# Altium schematic sheet MCU.SchDoc
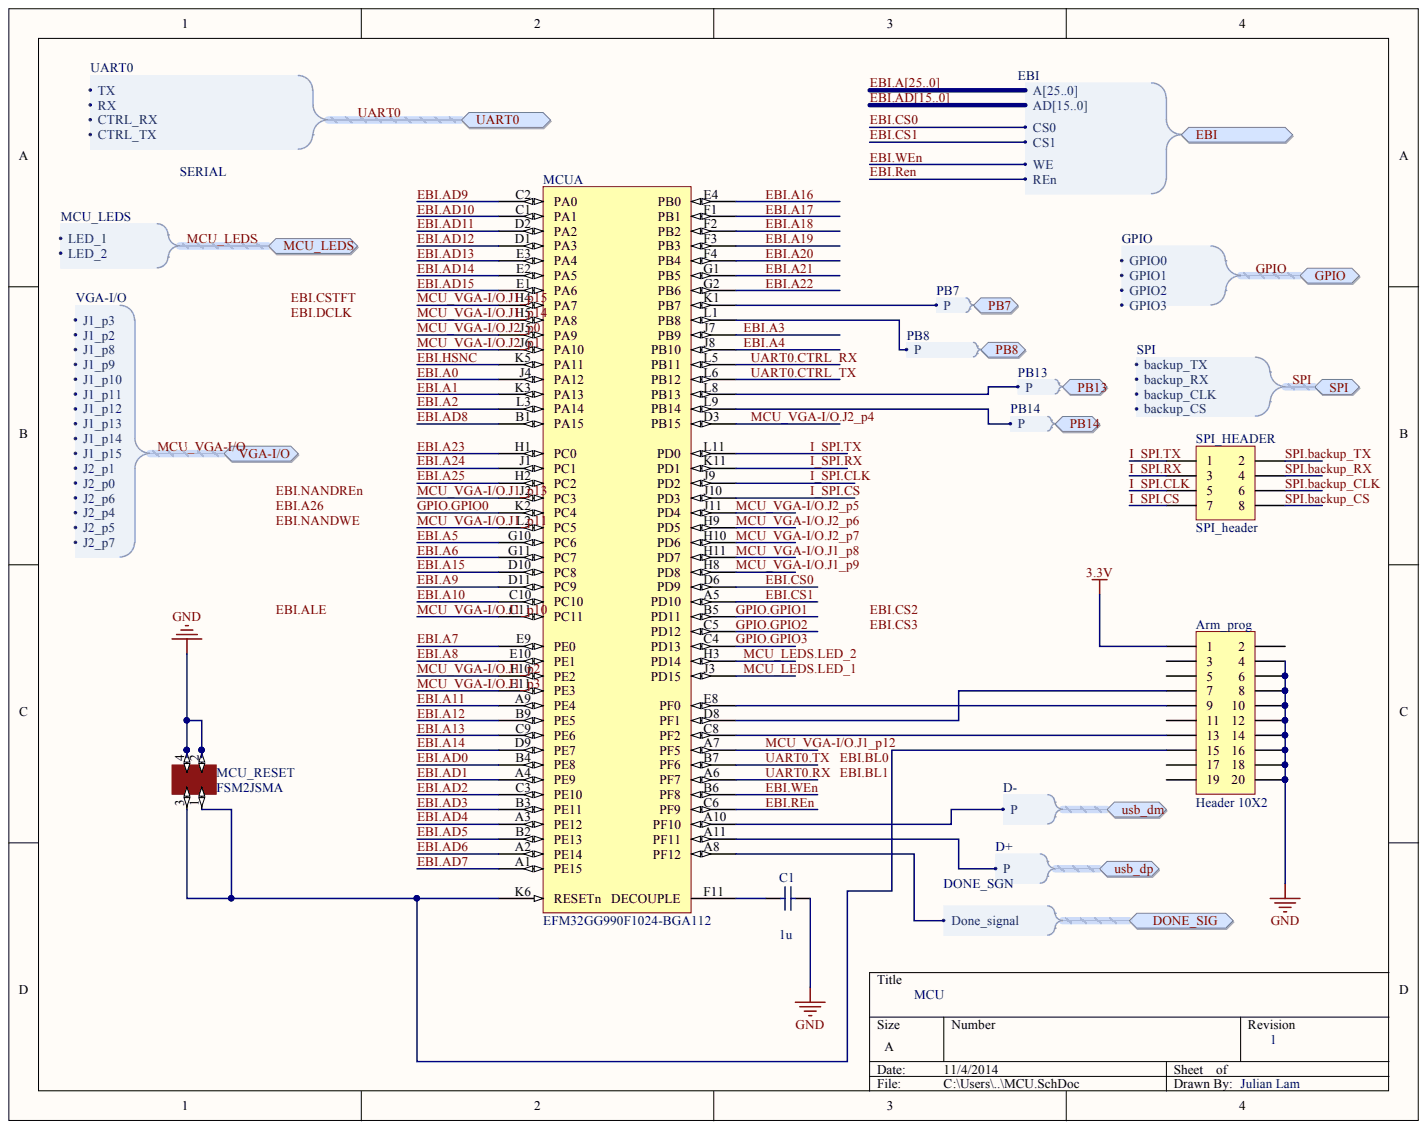
[diagram p0: MCU.SchDoc]

## Parts
Arm_prog  Header 10X2  [HDR2X10]
C1  value 1u  [C1206]
MCU  EFM32GG990F1024-BGA112  [ENMR-BGA112]  (part A)
MCU_RESET  FSM2JSMA  [Button]
SPI_HEADER  SPI_header  [HDR2X4]

## Ports
DONE_SIG, DONE_SIG.DONE_SIGNAL, EBI, EBI.A0, EBI.A1, EBI.A2, EBI.A3, EBI.A4, EBI.A5, EBI.A6, EBI.A7, EBI.A8, EBI.A9, EBI.A10, EBI.A11, EBI.A12, EBI.A13, EBI.A14, EBI.A15, EBI.A16, EBI.A17, EBI.A18, EBI.A19, EBI.A20, EBI.A21, EBI.A22, EBI.A23, EBI.A24, EBI.A25, EBI.A[25..0], EBI.AD0, EBI.AD1, EBI.AD2, EBI.AD3, EBI.AD4, EBI.AD5, EBI.AD6, EBI.AD7, EBI.AD8, EBI.AD9, EBI.AD10, EBI.AD11, EBI.AD12, EBI.AD13, EBI.AD14, EBI.AD15, EBI.AD[15..0], EBI.CS0, EBI.CS1, EBI.REN, EBI.WE, GPIO, GPIO.GPIO0, GPIO.GPIO1, GPIO.GPIO2, GPIO.GPIO3, MCU_LEDS, MCU_LEDS.LED_1, MCU_LEDS.LED_2, PB7, PB7.P, PB8, PB8.P, PB13, PB13.P, PB14, PB14.P, SPI, SPI.BACKUP_CLK, SPI.BACKUP_CS, SPI.BACKUP_RX, SPI.BACKUP_TX, UART0, UART0.CTRL_RX, UART0.CTRL_TX, UART0.RX, UART0.TX, USB_DM, USB_DM.P, USB_DP, USB_DP.P, VGA-I/O, VGA-I/O.J1_P2, VGA-I/O.J1_P3, VGA-I/O.J1_P8, VGA-I/O.J1_P9, VGA-I/O.J1_P10, VGA-I/O.J1_P11, VGA-I/O.J1_P12, VGA-I/O.J1_P13, VGA-I/O.J1_P14, VGA-I/O.J1_P15, VGA-I/O.J2_P0, VGA-I/O.J2_P1, VGA-I/O.J2_P4, VGA-I/O.J2_P5, VGA-I/O.J2_P6, VGA-I/O.J2_P7

## Nets
3.3V: Arm_prog.1
GND: Arm_prog.4 Arm_prog.6 Arm_prog.8 Arm_prog.10 Arm_prog.12 Arm_prog.14 Arm_prog.16 Arm_prog.18 Arm_prog.20 C1.2 MCU_RESET.2 MCU_RESET.4
DONE_SIG: MCU.A8(PF12) ; port DONE_SIG
EBI.A0: MCU.J4(PA12) ; port EBI  [also labelled EBI.A]
EBI.A1: MCU.K3(PA13) ; port EBI  [also labelled EBI.A]
EBI.A2: MCU.L3(PA14) ; port EBI  [also labelled EBI.A]
EBI.A3: MCU.J7(PB9) ; port EBI  [also labelled EBI.A]
EBI.A4: MCU.J8(PB10) ; port EBI  [also labelled EBI.A]
EBI.A5: MCU.G10(PC6) ; port EBI  [also labelled EBI.A]
EBI.A6: MCU.G11(PC7) ; port EBI  [also labelled EBI.A]
EBI.A7: MCU.E9(PE0) ; port EBI  [also labelled EBI.A]
EBI.A8: MCU.E10(PE1) ; port EBI  [also labelled EBI.A]
EBI.A9: MCU.D11(PC9) ; port EBI  [also labelled EBI.A]
EBI.A10: MCU.C10(PC10) ; port EBI  [also labelled EBI.A]
EBI.A11: MCU.A9(PE4) ; port EBI  [also labelled EBI.A]
EBI.A12: MCU.B9(PE5) ; port EBI  [also labelled EBI.A]
EBI.A13: MCU.C9(PE6) ; port EBI  [also labelled EBI.A]
EBI.A14: MCU.D9(PE7) ; port EBI  [also labelled EBI.A]
EBI.A15: MCU.D10(PC8) ; port EBI  [also labelled EBI.A]
EBI.A16: MCU.E4(PB0) ; port EBI  [also labelled EBI.A]
EBI.A17: MCU.F1(PB1) ; port EBI  [also labelled EBI.A]
EBI.A18: MCU.F2(PB2) ; port EBI  [also labelled EBI.A]
EBI.A19: MCU.F3(PB3) ; port EBI  [also labelled EBI.A]
EBI.A20: MCU.F4(PB4) ; port EBI  [also labelled EBI.A]
EBI.A21: MCU.G1(PB5) ; port EBI  [also labelled EBI.A]
EBI.A22: MCU.G2(PB6) ; port EBI  [also labelled EBI.A]
EBI.A23: MCU.H1(PC0) ; port EBI  [also labelled EBI.A]
EBI.A24: MCU.J1(PC1) ; port EBI  [also labelled EBI.A]
EBI.A25: MCU.H2(PC2) ; port EBI  [also labelled EBI.A]
EBI.AD0: MCU.B4(PE8) ; port EBI  [also labelled EBI.AD]
EBI.AD1: MCU.A4(PE9) ; port EBI  [also labelled EBI.AD]
EBI.AD2: MCU.C3(PE10) ; port EBI  [also labelled EBI.AD]
EBI.AD3: MCU.B3(PE11) ; port EBI  [also labelled EBI.AD]
EBI.AD4: MCU.A3(PE12) ; port EBI  [also labelled EBI.AD]
EBI.AD5: MCU.B2(PE13) ; port EBI  [also labelled EBI.AD]
EBI.AD6: MCU.A2(PE14) ; port EBI  [also labelled EBI.AD]
EBI.AD7: MCU.A1(PE15) ; port EBI  [also labelled EBI.AD]
EBI.AD8: MCU.B1(PA15) ; port EBI  [also labelled EBI.AD]
EBI.AD9: MCU.C2(PA0) ; port EBI  [also labelled EBI.AD]
EBI.AD10: MCU.C1(PA1) ; port EBI  [also labelled EBI.AD]
EBI.AD11: MCU.D2(PA2) ; port EBI  [also labelled EBI.AD]
EBI.AD12: MCU.D1(PA3) ; port EBI  [also labelled EBI.AD]
EBI.AD13: MCU.E3(PA4) ; port EBI  [also labelled EBI.AD]
EBI.AD14: MCU.E2(PA5) ; port EBI  [also labelled EBI.AD]
EBI.AD15: MCU.E1(PA6) ; port EBI  [also labelled EBI.AD]
EBI.CS0: MCU.D6(PD9) ; port EBI
EBI.CS1: MCU.A5(PD10) ; port EBI
EBI.HSNC: MCU.K5(PA11)
EBI.REN: MCU.C6(PF9) ; port EBI
EBI.WEN: MCU.B6(PF8) ; port EBI
GPIO.GPIO0: MCU.K2(PC4) ; port GPIO
GPIO.GPIO1: MCU.B5(PD11) ; port GPIO
GPIO.GPIO2: MCU.C5(PD12) ; port GPIO
GPIO.GPIO3: MCU.C4(PD13) ; port GPIO
I_SPI.CLK: MCU.J9(PD2) SPI_HEADER.5
I_SPI.CS: MCU.J10(PD3) SPI_HEADER.7
I_SPI.RX: MCU.K11(PD1) SPI_HEADER.3
I_SPI.TX: MCU.L11(PD0) SPI_HEADER.1
MCU_LEDS.LED_1: MCU.J3(PD15) ; port MCU_LEDS
MCU_LEDS.LED_2: MCU.H3(PD14) ; port MCU_LEDS
MCU_VGA-I/O.J1_P2: MCU.F10(PE2) ; port VGA-I/O
MCU_VGA-I/O.J1_P3: MCU.E11(PE3) ; port VGA-I/O
MCU_VGA-I/O.J1_P8: MCU.H11(PD7) ; port VGA-I/O
MCU_VGA-I/O.J1_P9: MCU.H8(PD8) ; port VGA-I/O
MCU_VGA-I/O.J1_P10: MCU.C11(PC11) ; port VGA-I/O
MCU_VGA-I/O.J1_P11: MCU.L2(PC5) ; port VGA-I/O
MCU_VGA-I/O.J1_P12: MCU.A7(PF5) ; port VGA-I/O
MCU_VGA-I/O.J1_P13: MCU.J2(PC3) ; port VGA-I/O
MCU_VGA-I/O.J1_P14: MCU.H5(PA8) ; port VGA-I/O
MCU_VGA-I/O.J1_P15: MCU.H4(PA7) ; port VGA-I/O
MCU_VGA-I/O.J2_P0: MCU.J5(PA9) ; port VGA-I/O
MCU_VGA-I/O.J2_P1: MCU.J6(PA10) ; port VGA-I/O
MCU_VGA-I/O.J2_P4: MCU.D3(PB15) ; port VGA-I/O
MCU_VGA-I/O.J2_P5: MCU.J11(PD4) ; port VGA-I/O
MCU_VGA-I/O.J2_P6: MCU.H9(PD5) ; port VGA-I/O
MCU_VGA-I/O.J2_P7: MCU.H10(PD6) ; port VGA-I/O
PB7: MCU.K1(PB7) ; port PB7
PB8: MCU.L1(PB8) ; port PB8
PB13: MCU.L8(PB13) ; port PB13
PB14: MCU.L9(PB14) ; port PB14
SPI.BACKUP_CLK: SPI_HEADER.6 ; port SPI
SPI.BACKUP_CS: SPI_HEADER.8 ; port SPI
SPI.BACKUP_RX: SPI_HEADER.4 ; port SPI
SPI.BACKUP_TX: SPI_HEADER.2 ; port SPI
UART0.CTRL_RX: MCU.L5(PB11) ; port UART0
UART0.CTRL_TX: MCU.L6(PB12) ; port UART0
UART0.RX: MCU.A6(PF7) ; port UART0
UART0.TX: MCU.B7(PF6) ; port UART0
NetArm_prog_7: Arm_prog.7 MCU.D8(PF1)
NetArm_prog_9: Arm_prog.9 MCU.E8(PF0)
NetArm_prog_13: Arm_prog.13 MCU.C8(PF2)
NetArm_prog_15: Arm_prog.15 MCU.K6(RESETn) MCU_RESET.1 MCU_RESET.3
NetC1_1: C1.1 MCU.F11(DECOUPLE)
NetMCU_A10: MCU.A10(PF10) ; port USB_DM
NetMCU_A11: MCU.A11(PF11) ; port USB_DP
EBI.A26:  [also labelled EBI.A]
EBI.ALE:
EBI.BL0:
EBI.BL1:
EBI.CS2:
EBI.CS3:
EBI.CSTFT:
EBI.DCLK:
EBI.NANDREN:
EBI.NANDWE:

Not connected: Arm_prog 2 3 5 11 17 19

## Library data of the parts
Arm_prog = Header 10X2: Header, 10-Pin, Dual row; Component Kind: Standard; LatestRevisionDate: 17-Jul-2002; LatestRevisionNote: Re-released for DXP Platform.; Library Name: Miscellaneous Connectors.IntLib; Signal Integrity: Connector; Publisher: Altium Limited
C1 = Cap Semi: Capacitor (Semiconductor SIM Model); Component Kind: Standard; LatestRevisionDate: 29-May-2009; LatestRevisionNote: IPC-7351 Footprint Added.; Library Name: Miscellaneous Devices.IntLib; PackageDescription: Chip Capacitor; PackageReference: C1206; Published: 8-Jun-2000; Signal Integrity: Cap Semi; Simulation: CAP; Publisher: Altium Limited
MCU = CMP-0270-00024-1: EFM32 Giant Gecko, M3 ARM Cortex CPU, 1024 KB Flash, 128 KB RAM, 1.85 - 3.8 V Supply, 48 MHz Max Speed, -40 to 85 degC, 112-Pin BGA, RoHS Compliant; Flash (KB): 1024; GPIO: 87; LCD: 8x34; LESENSE: Y; Max Speed (MHz): 48; OPAMPS: 3; RAM (KB): 128; ARM Cortex CPU: M3; Component Kind: Standard; ComponentLink1Description: Manufacturer URL; ComponentLink1URL: http://www.energymicro.com/; ComponentLink2Description: Datasheet; ComponentLink2URL: http://cdn.energymicro.com/dl/devices/pdf/d0046_efm32gg990_datasheet.pdf; ComponentLink3Description: Package Specification; ComponentLink3URL: http://cdn.energymicro.com/dl/devices/pdf/d0007_efm32g290_datasheet.pdf; DatasheetVersion: Rev 1.00; Generic Part Number: EFM32GG990; Library Name: Altium Content Vault; Mounting Technology: Surface Mount; PackageDescription: 112-Pin Ball Grid Array, Body 10 x 10 mm, Pitch 0.8 mm; PackageReference: BGA112; PackageVersion: Rev. 97SPP01315A_X03_06Jun11; PartNumber: EFM32GG990F1024-BGA112; Subcategory: EFM32 Giant Gecko; Supply Voltage (V): 1.85 - 3.8; USB: Y
MCU_RESET = switch-button: Reset button; Component Kind: Standard; Library Name: button.SchLib
SPI_HEADER = Header 4X2: Header, 4-Pin, Dual row; Component Kind: Standard; LatestRevisionDate: 17-Jul-2002; LatestRevisionNote: Re-released for DXP Platform.; Library Name: Miscellaneous Connectors.IntLib; Signal Integrity: Connector; Publisher: Altium Limited
